# Altium schematic sheet 11- IMU.SchDoc
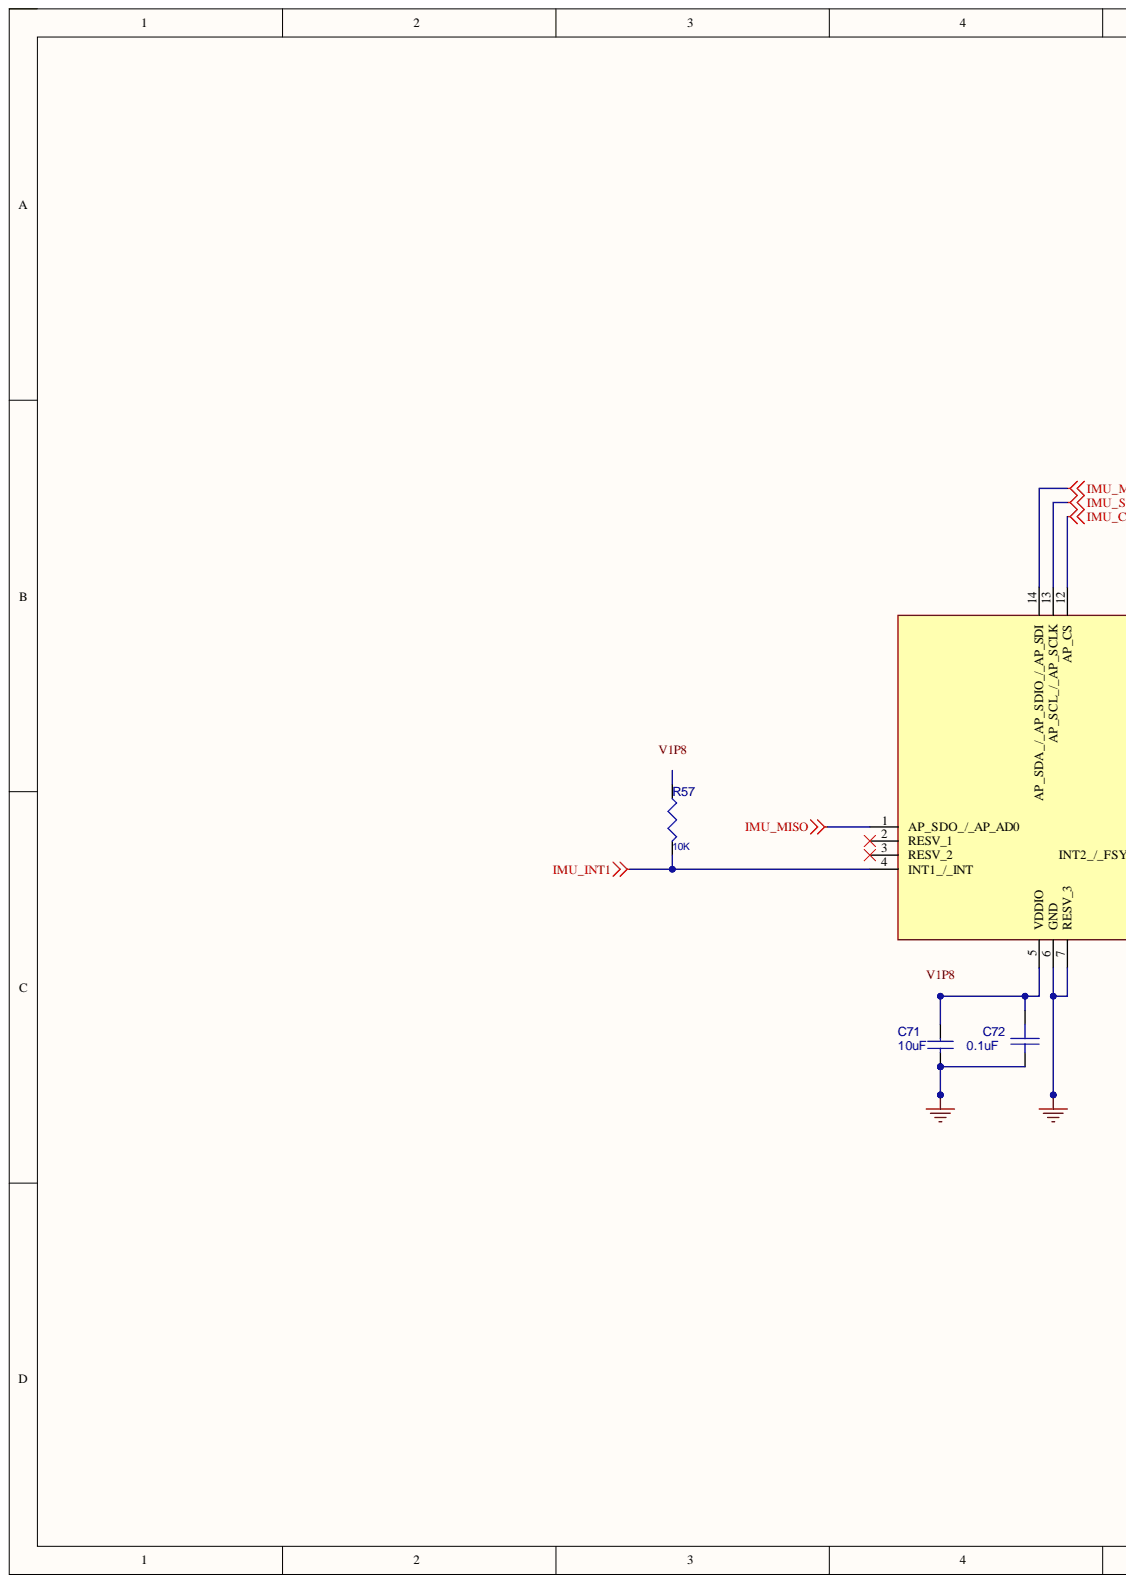
[diagram p0: 11- IMU.SchDoc, left]
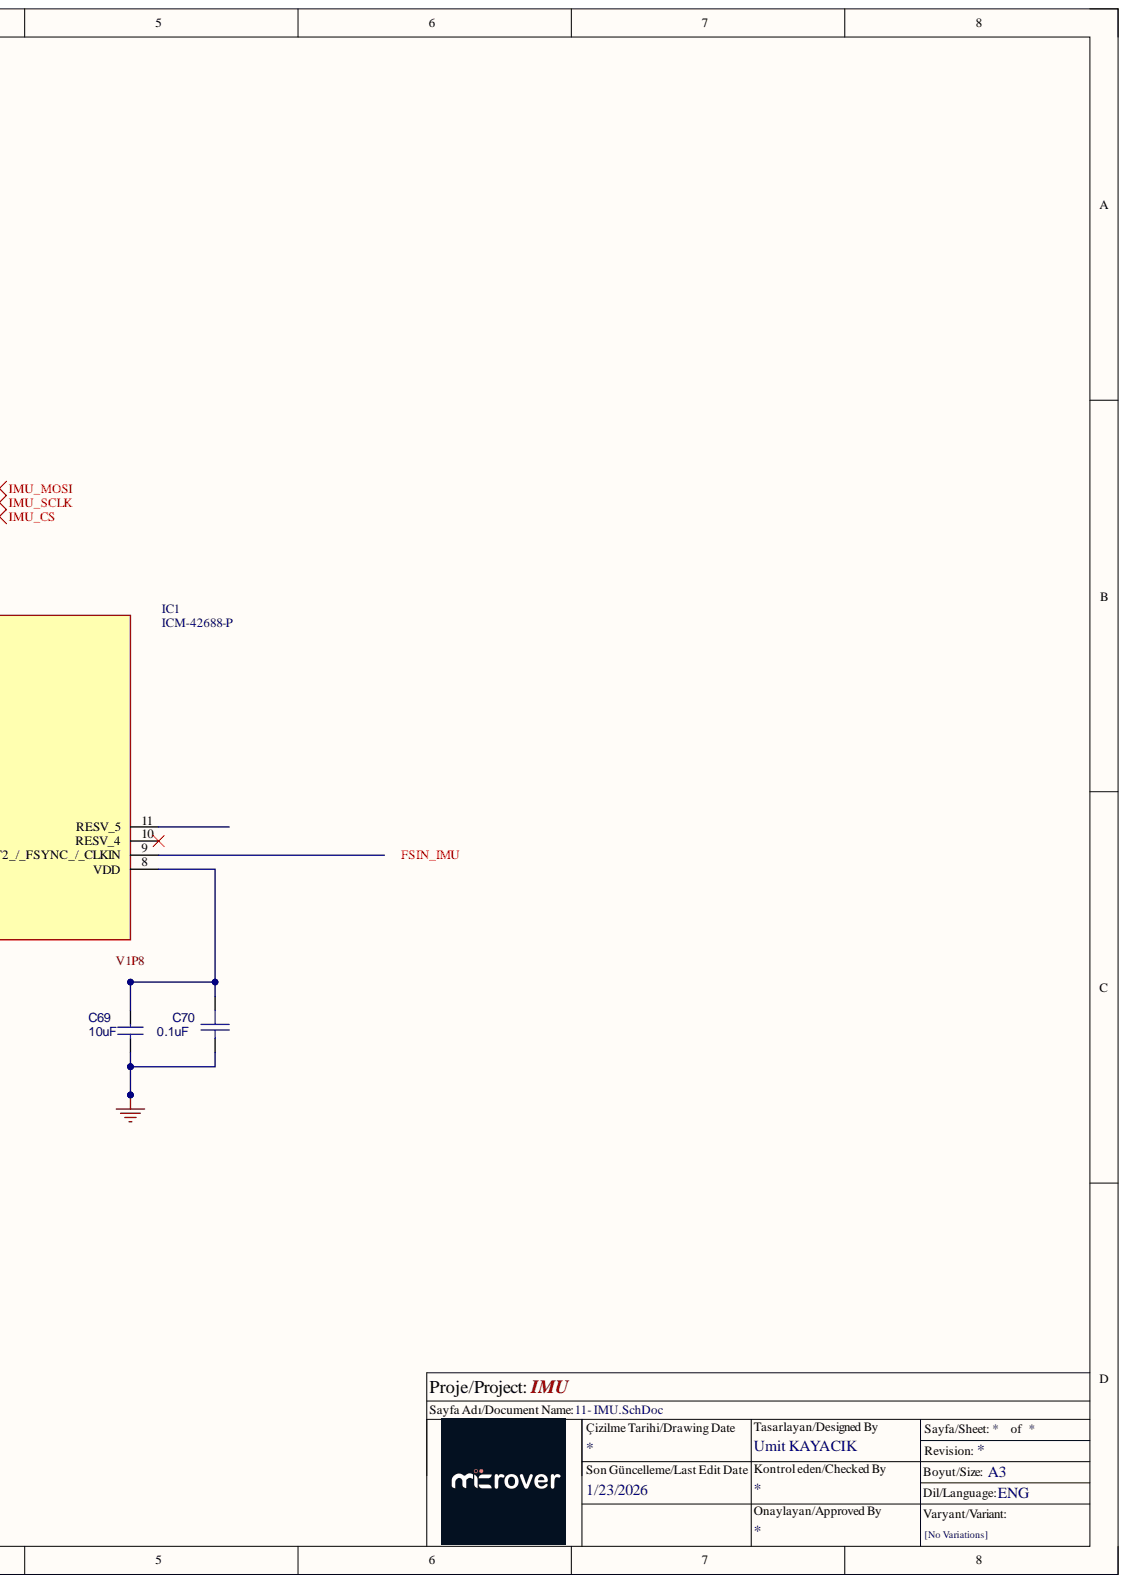
[diagram p1: 11- IMU.SchDoc, right]

## Parts
C69  10uF  [C0603]
C70  0.1uF  [C0201]
C71  10uF  [C0603]
C72  0.1uF  [C0201]
IC1  ICM-42688-P  [IIM42352]
R57  10K  [R0402]

## Nets
FSIN_IMU: IC1.9(INT2_/_FSYNC_/_CLKIN)
GND: C69.2 C70.2 C71.2 C72.2 IC1.6(GND) IC1.7(RESV_3) IC1.11(RESV_5)
IMU_CS: IC1.12(AP_CS)
IMU_INT1: IC1.4(INT1_/_INT) R57.2
IMU_MISO: IC1.1(AP_SDO_/_AP_AD0)
IMU_MOSI: IC1.14(AP_SDA_/_AP_SDIO_/_AP_SDI)
IMU_SCLK: IC1.13(AP_SCL_/_AP_SCLK)
V1P8: C69.1 C70.1 C71.1 C72.1 IC1.5(VDDIO) IC1.8(VDD) R57.1

Not connected: IC1 2(RESV_1) 3(RESV_2) 10(RESV_4)

## Library data of the parts
C69 C71 = CAP NP: CAP CER 10UF 10V 20% X6S 0603; Man. Part #: CL10X106MP8NRNC; Manufacturer: Samsung; Part Reference: C140; Assy: -; Component Kind: Standard; Manufacturer Part Number: CL10X106MP8NRNC; MFG: Samsung; MFG P/N: CL10X106MP8NRNC; PCB Footprint: C0603; Value: 10uF
C70 C72 = CAP_SMT_0805: CAP CER 0.1UF 25V 10% X5R 0201; Manufacturer: Murata; Manufacturer Part Number: GRM033R61E104KE14J; Part Reference: C120; Assy: -; Component Kind: Standard; PCB Footprint: C0201; Value: 0.1uF
IC1 = ICM-42688-P: Integrated Circuit; Manufacturer_Name: TDK; Arrow Part Number: ICM-42688-P; Arrow Price/Stock: https://www.arrow.com/en/products/icm-42688-p/invensense?utm_currency=USD&region=nac; Component Kind: Standard; Datasheet Link: https://3cfeqx1hf82y3xcoull08ihx-wpengine.netdna-ssl.com/wp-content/uploads/2021/06/DS-000347-ICM-42688-P-v1.5.pdf; Manufacturer_Part_Number: ICM-42688-P; Mouser Part Number: 410-ICM-42688-P; Mouser Price/Stock: https://www.mouser.co.uk/ProductDetail/TDK-InvenSense/ICM-42688-P?qs=OlC7AqGiEDk8QrNAV728zw%3D%3D; Library Name: Chronos.SchLib
R57 = RES_10K_1W16_0402_5P: RES 10K OHM 1/10W 5% 0603; Manufacturer: Stackpole Electronics Inc; Manufacturer Part Number: RMCF0603JT10K0; Part Reference: R10; DIST: FLAT; MAX_TEMP: RTMAX; MFG P/N: RMCF0402JT10K0; PCB Footprint: R0603; TC1: 0; Total Area(sq-mm): 0.5; Unit Price (USD): 0.02; Assy: -; Component Kind: Standard; Digikey P/N: RMCF0603JT10K0TR-ND; Length(mm): 1; MFG: Stackpole Electronics Inc; TC2: 0; Value: 10K; Wattage: 1/10W; Width(mm): 0.5
